# Altium schematic sheet charge_drv.SchDoc
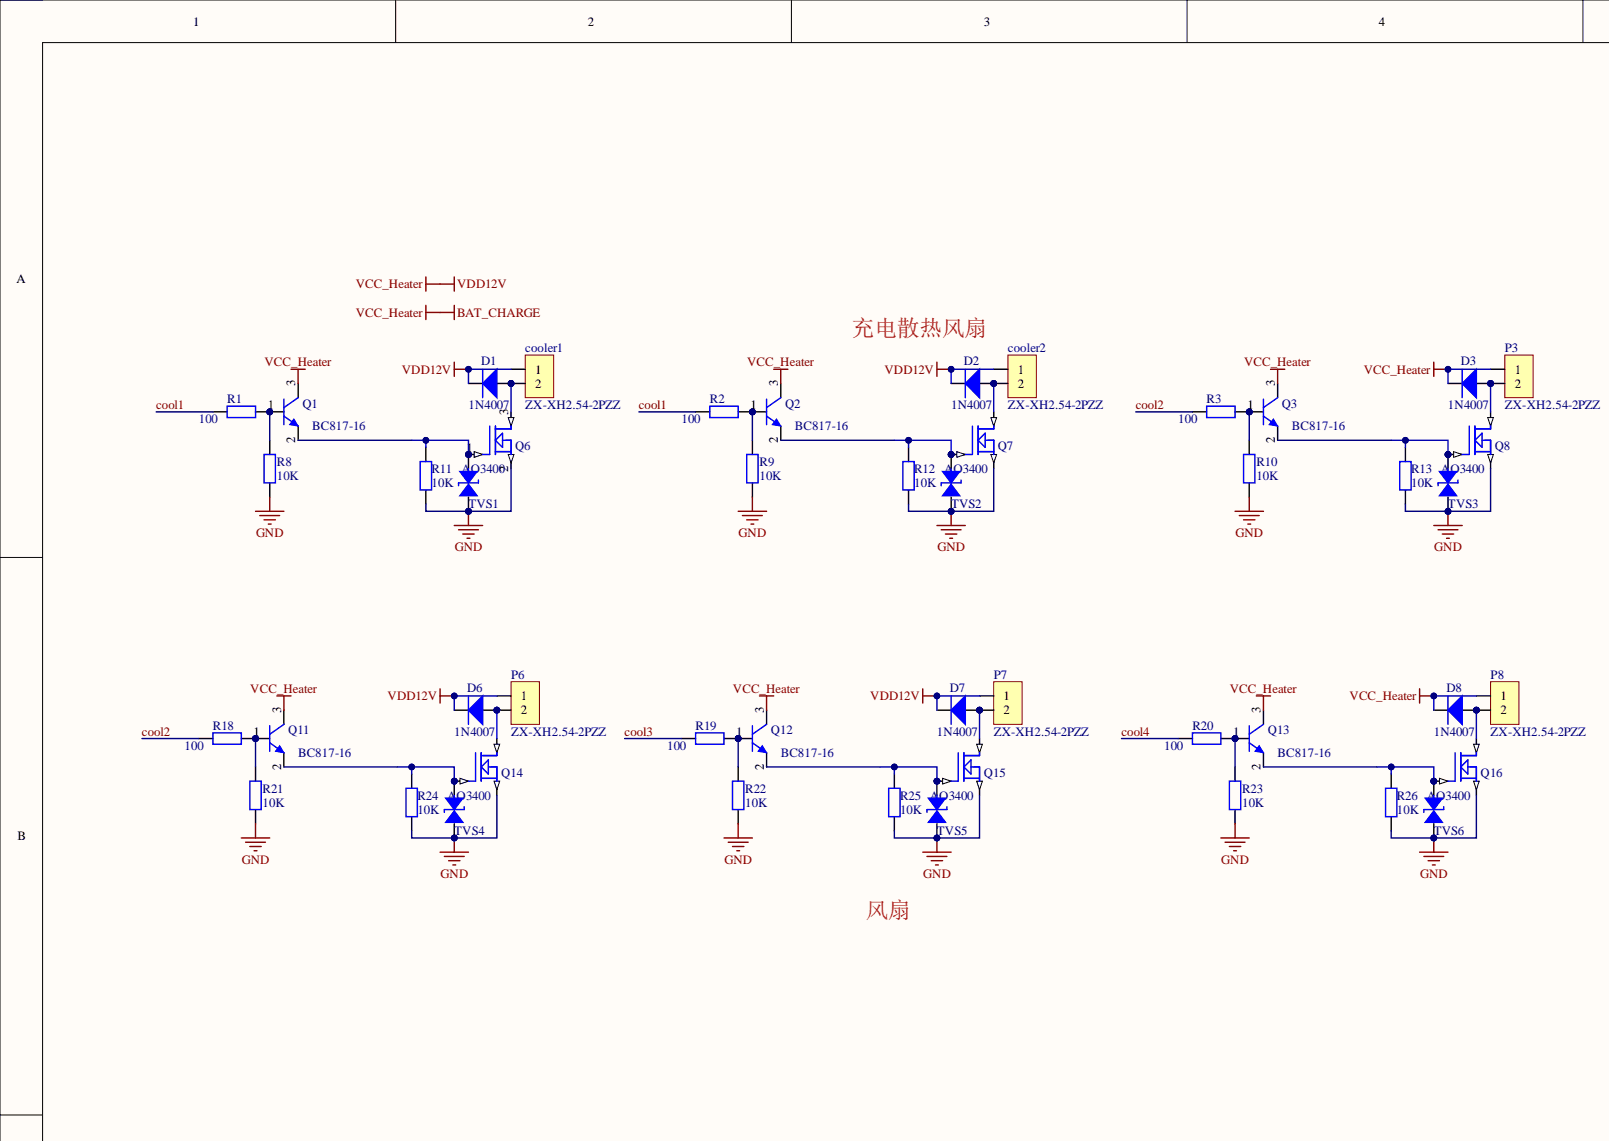
[diagram p0: charge_drv.SchDoc, top left]
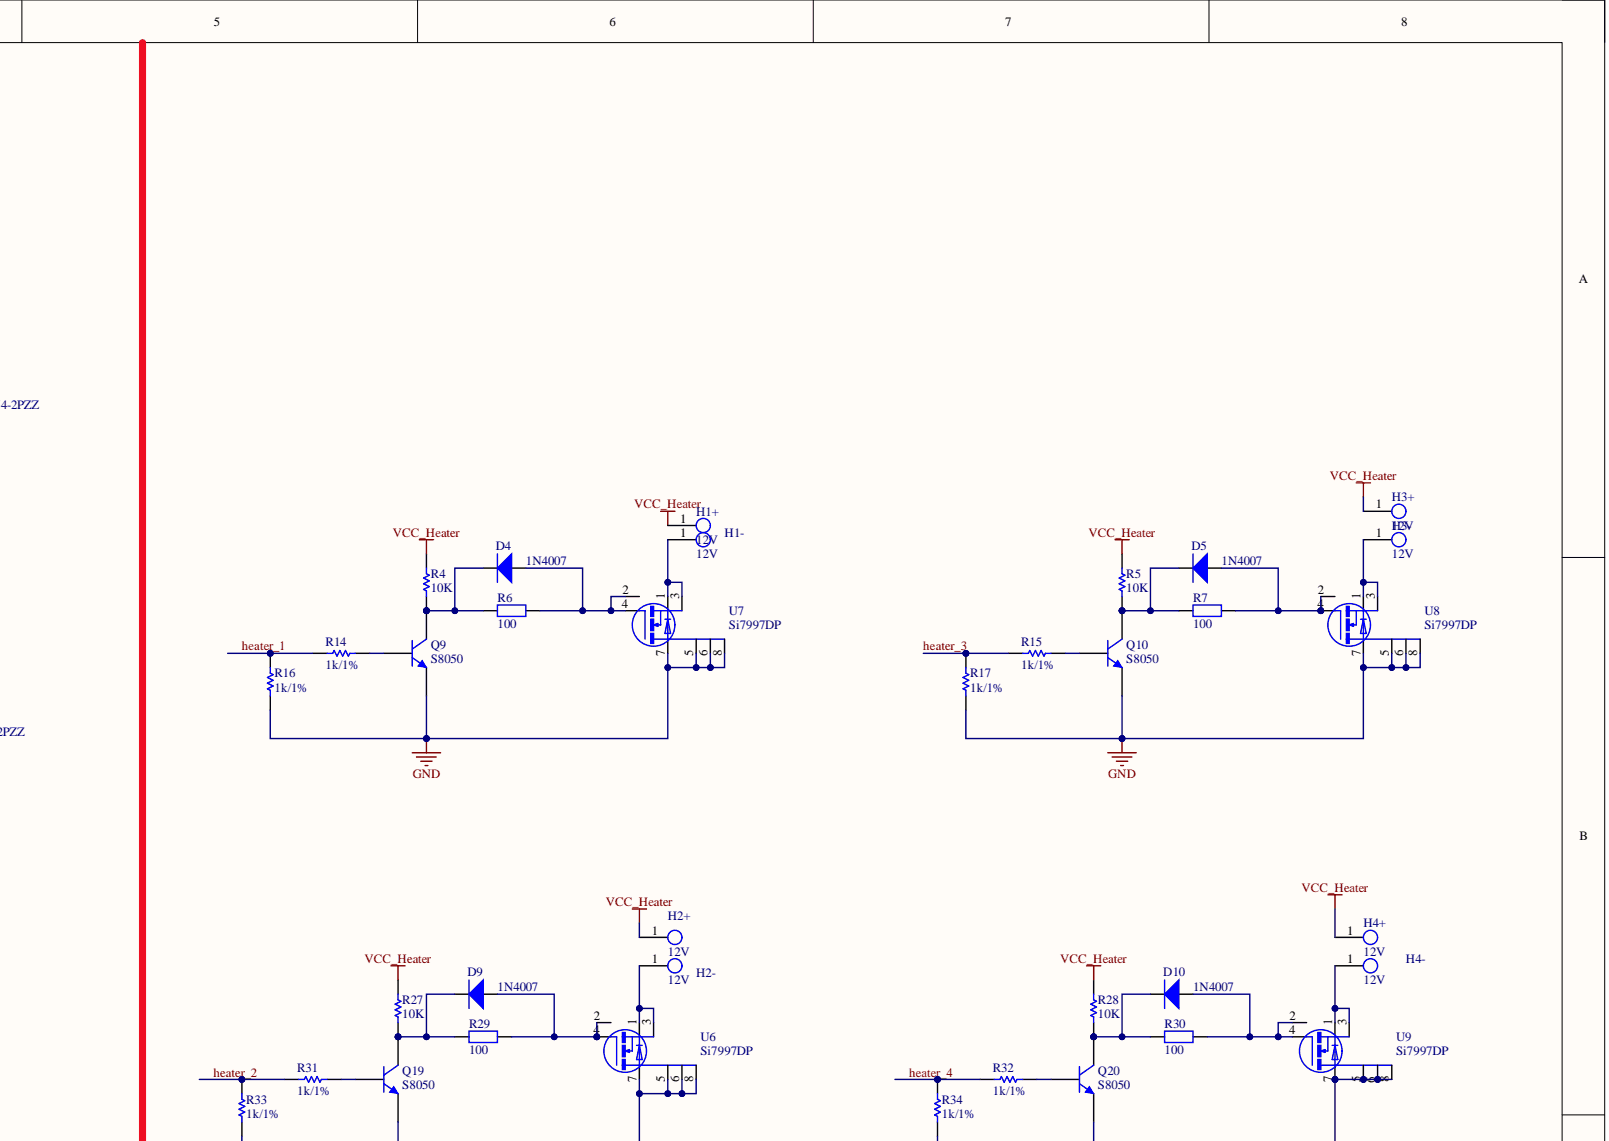
[diagram p1: charge_drv.SchDoc, top right]
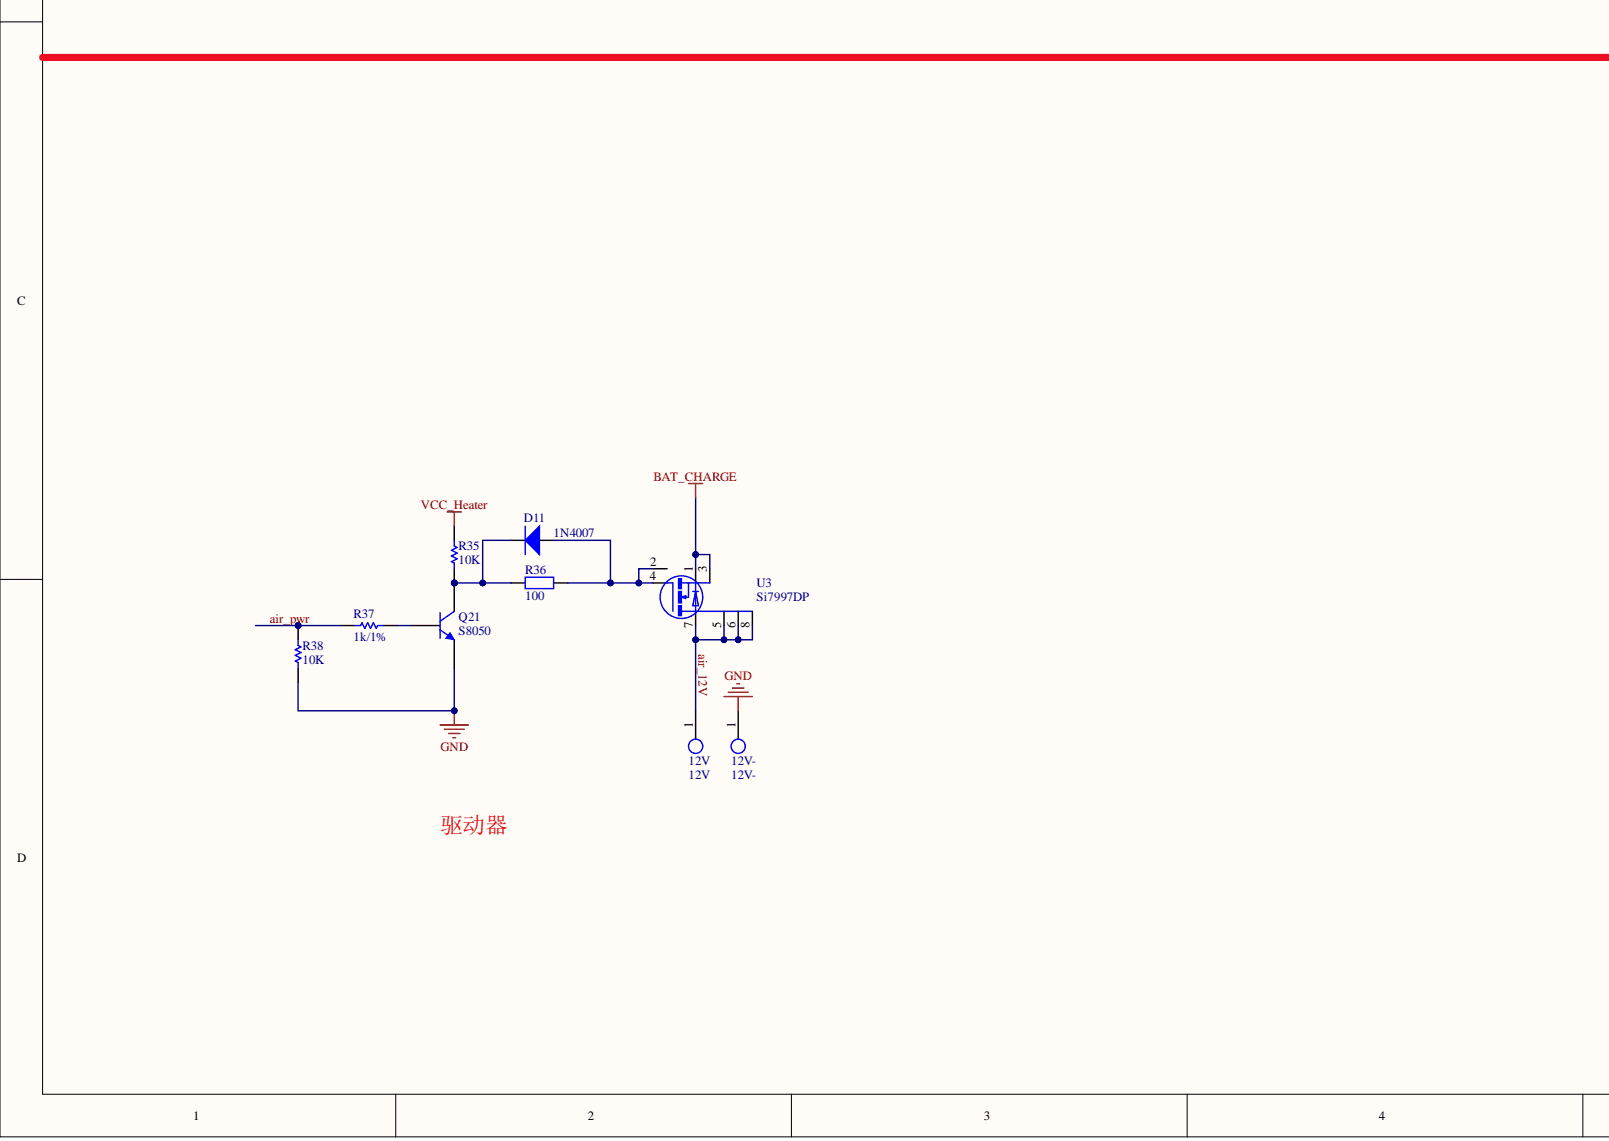
[diagram p2: charge_drv.SchDoc, bottom left]
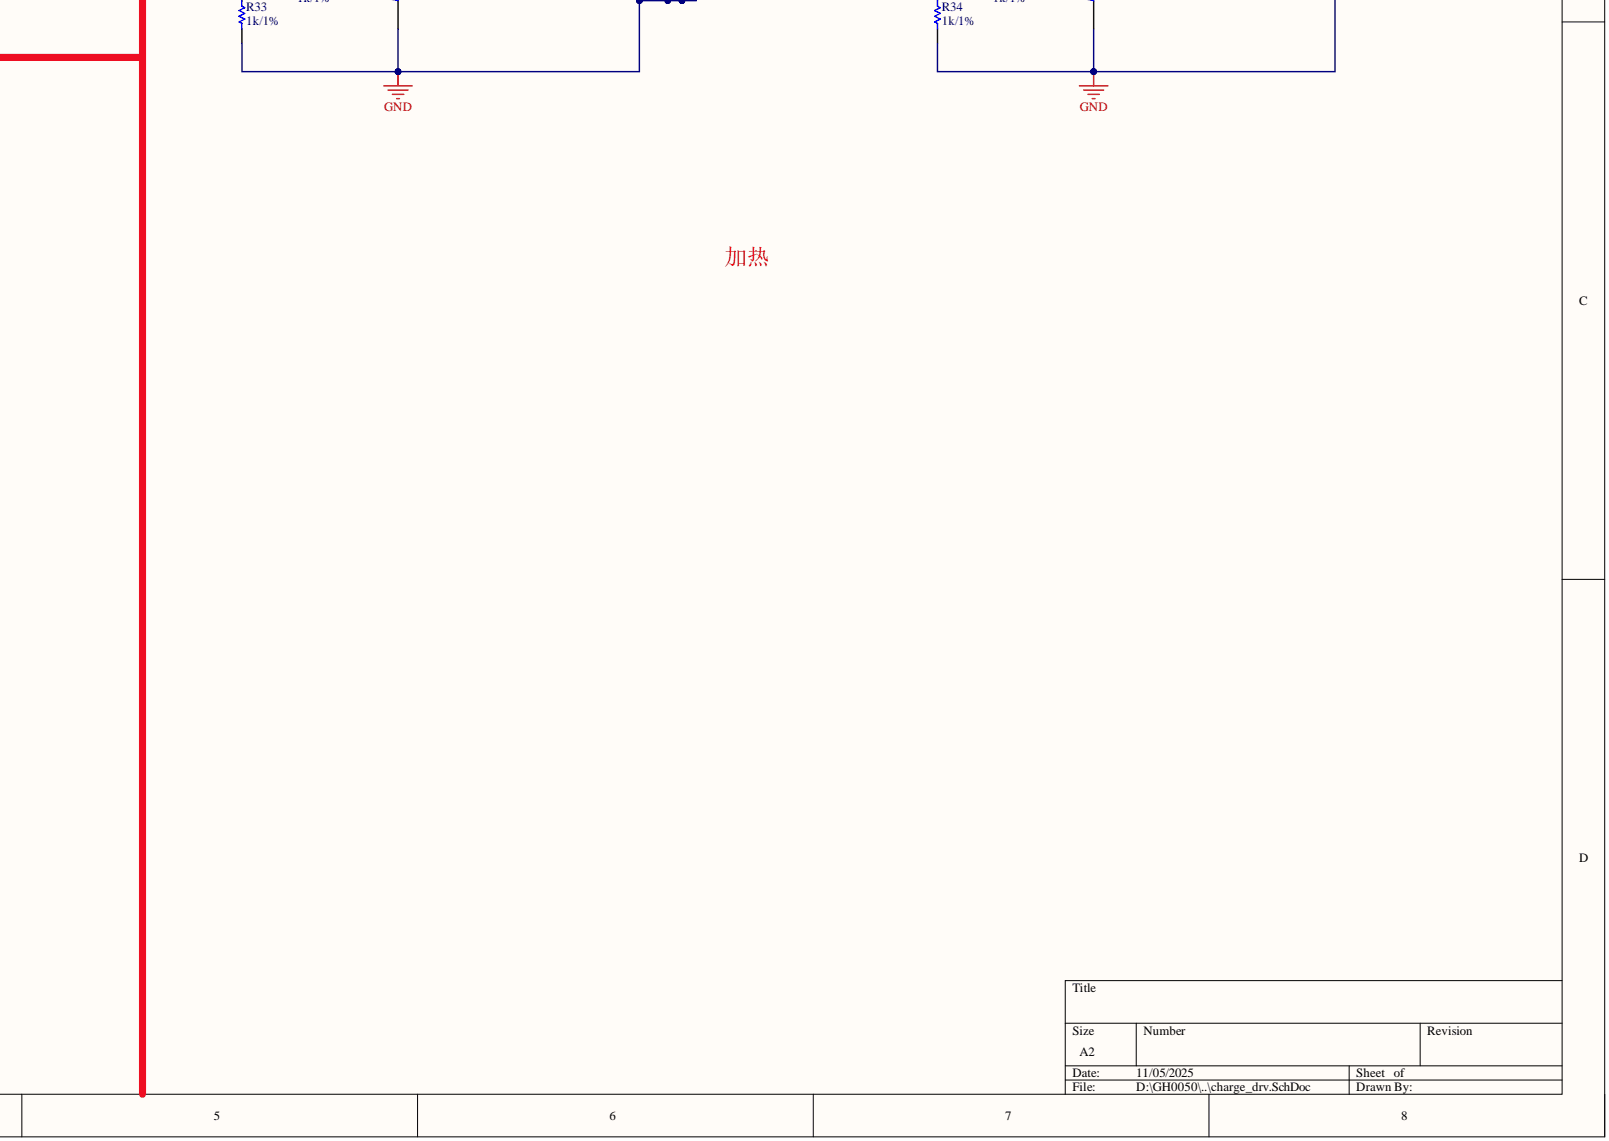
[diagram p3: charge_drv.SchDoc, bottom right]

## Parts
12V  12V  [FIX-4.5]  (no BOM)
12V-  12V-  [FIX-4.5]  (no BOM)
cooler1  ZX-XH2.54-2PZZ  [SIP-2A]
cooler2  ZX-XH2.54-2PZZ  [SIP-2A]
D1  1N4007  [DO-214-AC]
D2  1N4007  [DO-214-AC]
D3  1N4007  [DO-214-AC]
D4  1N4007  [DO-214-AC]
D5  1N4007  [DO-214-AC]
D6  1N4007  [DO-214-AC]
D7  1N4007  [DO-214-AC]
D8  1N4007  [DO-214-AC]
D9  1N4007  [DO-214-AC]
D10  1N4007  [DO-214-AC]
D11  1N4007  [DO-214-AC]
H1+  12V  [FIX-4.5]  (no BOM)
H1-  12V  [FIX-4.5]  (no BOM)
H2+  12V  [FIX-4.5]  (no BOM)
H2-  12V  [FIX-4.5]  (no BOM)
H3+  12V  [FIX-4.5]  (no BOM)
H3-  12V  [FIX-4.5]  (no BOM)
H4+  12V  [FIX-4.5]  (no BOM)
H4-  12V  [FIX-4.5]  (no BOM)
P3  ZX-XH2.54-2PZZ  [SIP-2A]
P6  ZX-XH2.54-2PZZ  [SIP-2A]
P7  ZX-XH2.54-2PZZ  [SIP-2A]
P8  ZX-XH2.54-2PZZ  [SIP-2A]
Q1  BC817-16  [SOT23-3]
Q2  BC817-16  [SOT23-3]
Q3  BC817-16  [SOT23-3]
Q6  AO3400  [SOT23-3]
Q7  AO3400  [SOT23-3]
Q8  AO3400  [SOT23-3]
Q9  S8050  [SOT23-3]
Q10  S8050  [SOT23-3]
Q11  BC817-16  [SOT23-3]
Q12  BC817-16  [SOT23-3]
Q13  BC817-16  [SOT23-3]
Q14  AO3400  [SOT23-3]
Q15  AO3400  [SOT23-3]
Q16  AO3400  [SOT23-3]
Q19  S8050  [SOT23-3]
Q20  S8050  [SOT23-3]
Q21  S8050  [SOT23-3]
R1  100  [0603R]
R2  100  [0603R]
R3  100  [0603R]
R4  10K  [0603R]
R5  10K  [0603R]
R6  100  [0603R]
R7  100  [0603R]
R8  10K  [0603R]
R9  10K  [0603R]
R10  10K  [0603R]
R11  10K  [0603R]
R12  10K  [0603R]
R13  10K  [0603R]
R14  1k/1%  [0603R]
R15  1k/1%  [0603R]
R16  1k/1%  [0603R]
R17  1k/1%  [0603R]
R18  100  [0603R]
R19  100  [0603R]
R20  100  [0603R]
R21  10K  [0603R]
R22  10K  [0603R]
R23  10K  [0603R]
R24  10K  [0603R]
R25  10K  [0603R]
R26  10K  [0603R]
R27  10K  [0603R]
R28  10K  [0603R]
R29  100  [0603R]
R30  100  [0603R]
R31  1k/1%  [0603R]
R32  1k/1%  [0603R]
R33  1k/1%  [0603R]
R34  1k/1%  [0603R]
R35  10K  [0603R]
R36  100  [0603R]
R37  1k/1%  [0603R]
R38  10K  [0603R]
TVS1  ESD5Z5.0CT1G  [SOD-523]  (value not drawn)
TVS2  ESD5Z5.0CT1G  [SOD-523]  (value not drawn)
TVS3  ESD5Z5.0CT1G  [SOD-523]  (value not drawn)
TVS4  ESD5Z5.0CT1G  [SOD-523]  (value not drawn)
TVS5  ESD5Z5.0CT1G  [SOD-523]  (value not drawn)
TVS6  ESD5Z5.0CT1G  [SOD-523]  (value not drawn)
U3  Si7997DP  [PowerPAK SO-8]
U6  Si7997DP  [PowerPAK SO-8]
U7  Si7997DP  [PowerPAK SO-8]
U8  Si7997DP  [PowerPAK SO-8]
U9  Si7997DP  [PowerPAK SO-8]

## Nets
BAT_CHARGE: cooler1.1 cooler2.1 D1.2 D2.2 D3.2 D6.2 D7.2 D8.2 H1+.1 H2+.1 H3+.1 H4+.1 P3.1 P6.1 P7.1 P8.1 Q1.3 Q2.3 Q3.3 Q11.3 Q12.3 Q13.3 R4.2 R5.2 R27.2 R28.2 R35.2 U3.1 U3.3
GND: 12V-.1 Q6.2 Q7.2 Q8.2 Q9.2 Q10.2 Q14.2 Q15.2 Q16.2 Q19.2 Q20.2 Q21.2 R8.1 R9.1 R10.1 R11.2 R12.2 R13.2 R16.2 R17.2 R21.1 R22.1 R23.1 R24.2 R25.2 R26.2 R33.2 R34.2 R38.2 TVS1.1 TVS2.1 TVS3.1 TVS4.1 TVS5.1 TVS6.1 U6.5 U6.6 U6.7 U6.8 U7.5 U7.6 U7.7 U7.8 U8.5 U8.6 U8.7 U8.8 U9.5 U9.6 U9.7 U9.8
air_12V: 12V.1 U3.5 U3.6 U3.7 U3.8
air_pwr: R37.2 R38.1
cool1: R1.2 R2.2
cool2: R3.2 R18.2
cool3: R19.2
cool4: R20.2
heater_1: R14.2 R16.1
heater_2: R31.2 R33.1
heater_3: R15.2 R17.1
heater_4: R32.2 R34.1
Netcooler1_2: cooler1.2 D1.1 Q6.3
Netcooler2_2: cooler2.2 D2.1 Q7.3
NetD3_1: D3.1 P3.2 Q8.3
NetD4_1: D4.1 R6.2 U7.2 U7.4
NetD4_2: D4.2 Q9.3 R4.1 R6.1
NetD5_1: D5.1 R7.2 U8.2 U8.4
NetD5_2: D5.2 Q10.3 R5.1 R7.1
NetD6_1: D6.1 P6.2 Q14.3
NetD7_1: D7.1 P7.2 Q15.3
NetD8_1: D8.1 P8.2 Q16.3
NetD9_1: D9.1 R29.2 U6.2 U6.4
NetD9_2: D9.2 Q19.3 R27.1 R29.1
NetD10_1: D10.1 R30.2 U9.2 U9.4
NetD10_2: D10.2 Q20.3 R28.1 R30.1
NetD11_1: D11.1 R36.2 U3.2 U3.4
NetD11_2: D11.2 Q21.3 R35.1 R36.1
NetH1-_1: H1-.1 U7.1 U7.3
NetH2-_1: H2-.1 U6.1 U6.3
NetH3-_1: H3-.1 U8.1 U8.3
NetH4-_1: H4-.1 U9.1 U9.3
NetQ1_1: Q1.1 R1.1 R8.2
NetQ1_2: Q1.2 Q6.1 R11.1 TVS1.2
NetQ2_1: Q2.1 R2.1 R9.2
NetQ2_2: Q2.2 Q7.1 R12.1 TVS2.2
NetQ3_1: Q3.1 R3.1 R10.2
NetQ3_2: Q3.2 Q8.1 R13.1 TVS3.2
NetQ9_1: Q9.1 R14.1
NetQ10_1: Q10.1 R15.1
NetQ11_1: Q11.1 R18.1 R21.2
NetQ11_2: Q11.2 Q14.1 R24.1 TVS4.2
NetQ12_1: Q12.1 R19.1 R22.2
NetQ12_2: Q12.2 Q15.1 R25.1 TVS5.2
NetQ13_1: Q13.1 R20.1 R23.2
NetQ13_2: Q13.2 Q16.1 R26.1 TVS6.2
NetQ19_1: Q19.1 R31.1
NetQ20_1: Q20.1 R32.1
NetQ21_1: Q21.1 R37.1

## Library data of the parts
12V 12V- H1+ H1- H2+ H2- H3+ H3- H4+ H4- = TP: Library Name: Schlib_20110825.schlib
cooler1 cooler2 P3 P6-P8 = SIP-2: XH2.54 2P 立贴; Component Kind: Standard; Library Name: XiaoyI.SchLib
D1-D11 = DIODE2: 贴片二极管; Component Kind: Standard; Library Name: XiaoyI.SchLib
Q1-Q3 Q11-Q13 = NPN-1: 三极管; Component Kind: Standard; Library Name: XiaoyI.SchLib
Q6-Q8 Q14-Q16 = MOSFET N: Component Kind: Standard; Description: MOSFET N; Library Name: XiaoyI.SchLib
Q9 Q10 Q19-Q21 = NPN: NPN Bipolar Transistor; Code_JEDEC: TO-226-AA; Component Kind: Standard; LatestRevisionDate: 17-Jul-2002; LatestRevisionNote: Re-released for DXP Platform.; Library Name: Miscellaneous Devices.IntLib; PackageReference: TO-226; Published: 8-Jun-2000; Signal Integrity: NPN; Simulation: NPN; Publisher: Altium Limited
R1-R3 R6-R13 R18-R26 R29 R30 R36 = RES-SOP: 贴片电阻 5%; Component Kind: Standard; Library Name: XiaoyI.SchLib
R4 R5 R14-R17 R27 R28 R31-R35 R37 R38 = Res1: Resistor; Component Kind: Standard; LatestRevisionDate: 17-Jul-2002; LatestRevisionNote: Re-released for DXP Platform.; Library Name: Miscellaneous Devices.IntLib; PackageReference: AXIAL-0.3; Published: 8-Jun-2000; Signal Integrity: Res; Simulation: RESISTOR; Publisher: Altium Limited
TVS1-TVS6 = TVS-1: TVS; Component Kind: Standard; Library Name: XiaoyI.SchLib
U3 U6-U9 = Si7997DP: Sia430DJ; Component Kind: Standard; Library Name: Schlib_20110825.schlib
